# Altium schematic sheet Connectors.SchDoc
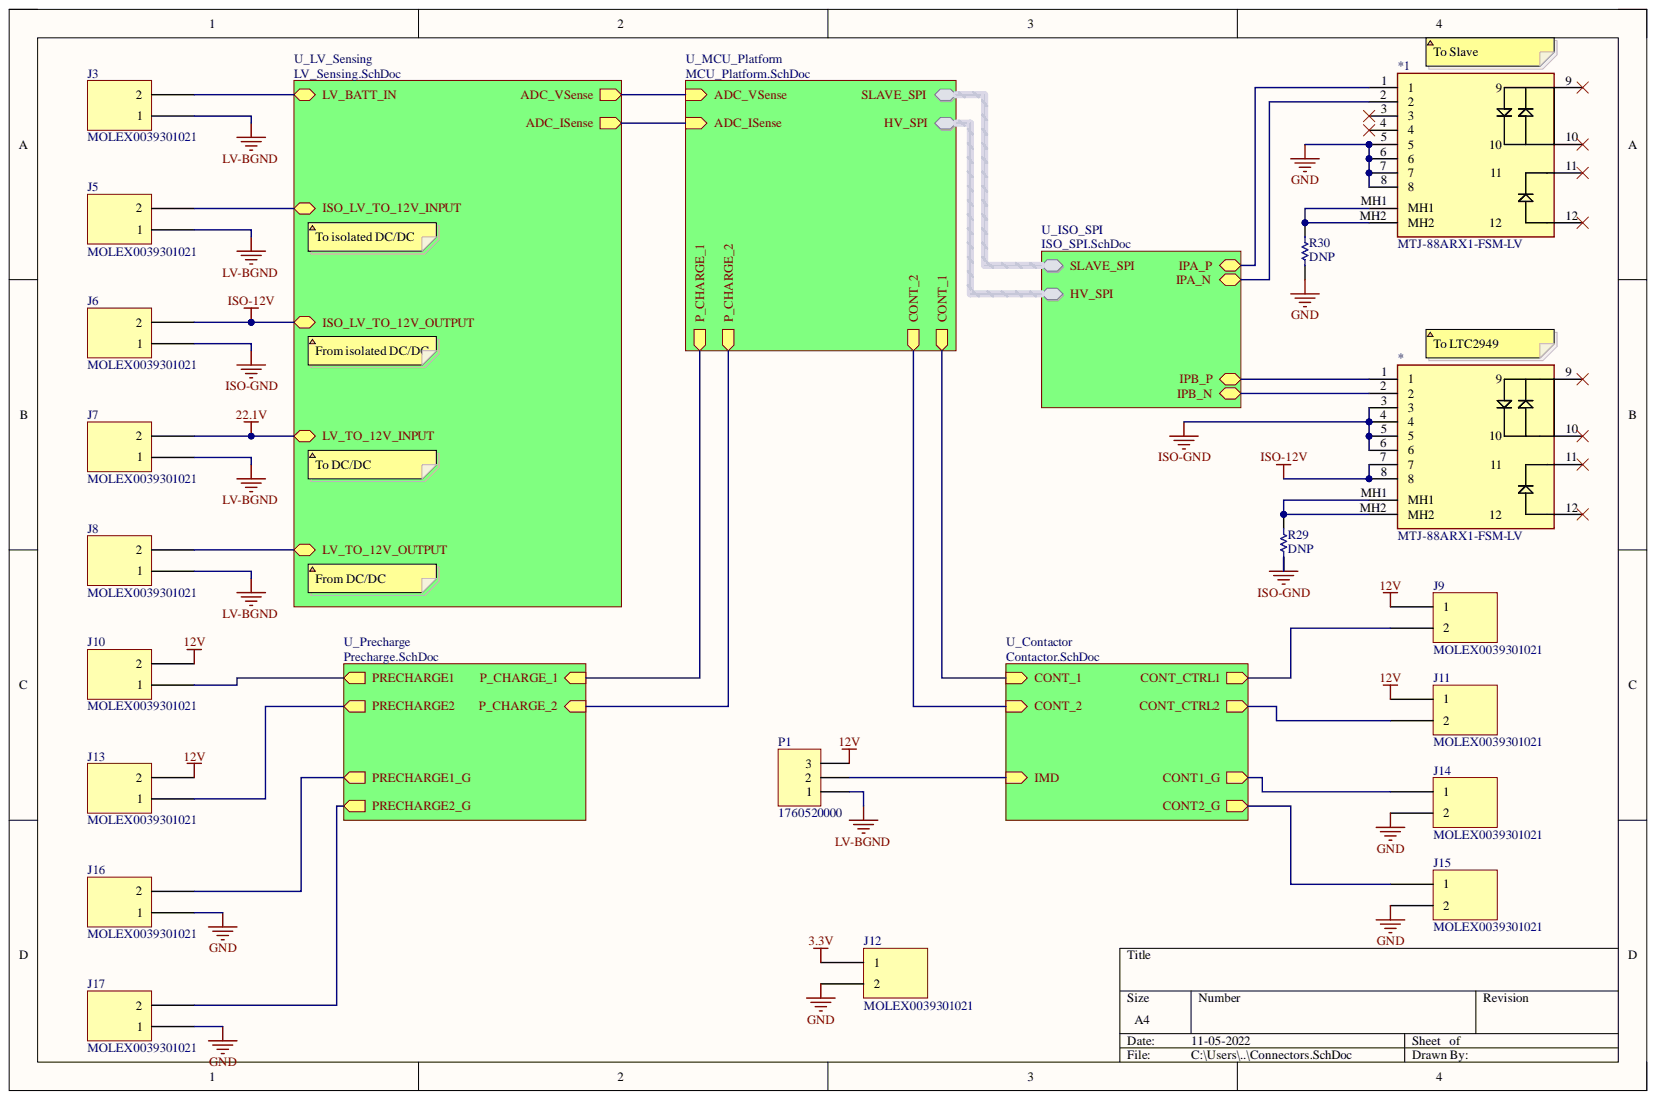
[diagram p0: Connectors.SchDoc]

## Parts
*  MTJ-88ARX1-FSM-LV  [MTJ-88ARX1-FSM-LV]
*1  MTJ-88ARX1-FSM-LV  [MTJ-88ARX1-FSM-LV]
J3  MOLEX0039301021  [MOLEX0039301021]
J5  MOLEX0039301021  [MOLEX0039301021]
J6  MOLEX0039301021  [MOLEX0039301021]
J7  MOLEX0039301021  [MOLEX0039301021]
J8  MOLEX0039301021  [MOLEX0039301021]
J9  MOLEX0039301021  [MOLEX0039301021]
J10  MOLEX0039301021  [MOLEX0039301021]
J11  MOLEX0039301021  [MOLEX0039301021]
J12  MOLEX0039301021  [MOLEX0039301021]
J13  MOLEX0039301021  [MOLEX0039301021]
J14  MOLEX0039301021  [MOLEX0039301021]
J15  MOLEX0039301021  [MOLEX0039301021]
J16  MOLEX0039301021  [MOLEX0039301021]
J17  MOLEX0039301021  [MOLEX0039301021]
P1  1760520000  [1760520000]
R29  value DNP  [0603 - Resistor]  (hidden comment: Resistor)
R30  value DNP  [0603 - Resistor]  (hidden comment: Resistor)

## Nets
3.3V: J12.1
12V: J9.1 J10.2 J11.1 J13.2 P1.3
22.1V: J7.2
GND: *1.5 *1.6 *1.7 *1.8 J12.2 J14.2 J15.2 J16.1 J17.1 R30.1
ISO-12V: *.7 *.8 J6.2
ISO-GND: *.3 *.4 *.5 *.6 J6.1 R29.1
LV-BGND: J3.1 J5.1 J7.1 J8.1 P1.1
Net*1_1: *1.1
Net*1_2: *1.2
Net*1_3: *1.3
Net*1_4: *1.4
Net*1_9: *1.9
Net*1_10: *1.10
Net*1_11: *1.11
Net*1_12: *1.12
Net*1_MH1: *1.MH1 *1.MH2 R30.2
Net*_1: *.1
Net*_2: *.2
Net*_9: *.9
Net*_10: *.10
Net*_11: *.11
Net*_12: *.12
Net*_MH1: *.MH1 *.MH2 R29.2
NetJ3_2: J3.2
NetJ5_2: J5.2
NetJ8_2: J8.2
NetJ9_2: J9.2
NetJ10_1: J10.1
NetJ11_2: J11.2
NetJ13_1: J13.1
NetJ14_1: J14.1
NetJ15_1: J15.1
NetJ16_2: J16.2
NetJ17_2: J17.2
NetP1_2: P1.2
ADC_ISense:
ADC_VSense:
CONT_1:
CONT_2:
HV_SPI.HV_CS:
HV_SPI.HV_MISO:
HV_SPI.HV_MOSI:
HV_SPI.HV_SCK:
P_CHARGE_1:
P_CHARGE_2:
SLAVE_SPI.SLAVE_CS:
SLAVE_SPI.SLAVE_MISO:
SLAVE_SPI.SLAVE_MOSI:
SLAVE_SPI.SLAVE_SCK:

## Library data of the parts
* *1 = MTJ-88ARX1-FSM-LV: RJ45 Connector; Component Kind: Standard; Library Name: GeneralConnectors.SchLib
J3 J5-J17 = MOLEX0039301021: Connector Header Through Hole, Right Angle 2 pin 0.165" (4.20mm); Component Kind: Standard; Library Name: GeneralConnectors.SchLib
P1 = 1760520000: 1x3 Screw Terminal Block; Manufacturer: Weidmüller; Component Kind: Standard; ComponentLink1Description: Datasheet; ComponentLink1URL: https://media.digikey.com/pdf/Data%20Sheets/Weidmuller%20PDFs/1760520000.pdf; Library Name: GeneralConnectors.SchLib; Manufacturer Part Number: 1760520000; Supplier: Digikey; Supplier Part Number: 281-1883-ND
R29 R30 = Resistor: Component Kind: Standard; Description: Resistor; Library Name: General.SchLib; Signal Integrity: Res; Simulation: RESISTOR
